# Altium schematic sheet ATT-Light.SchDoc(ATT-Light)
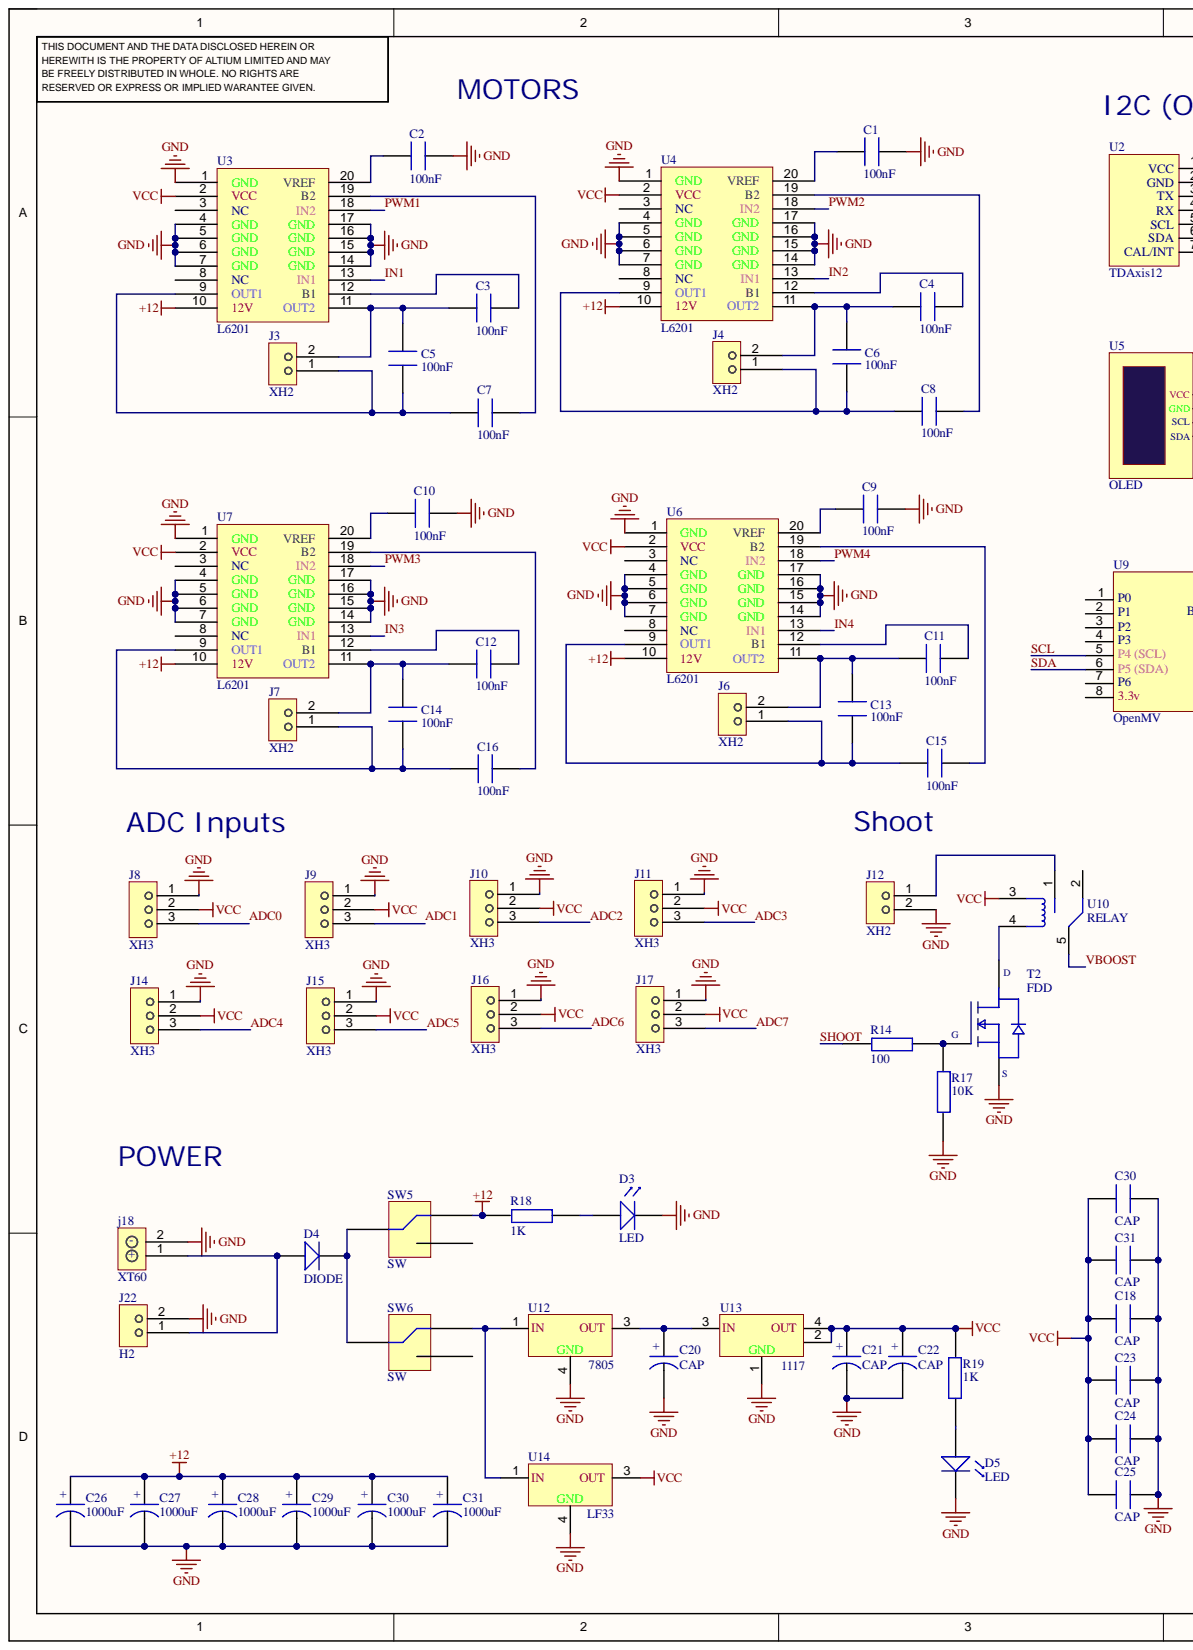
[diagram p0: ATT-Light.SchDoc, left]
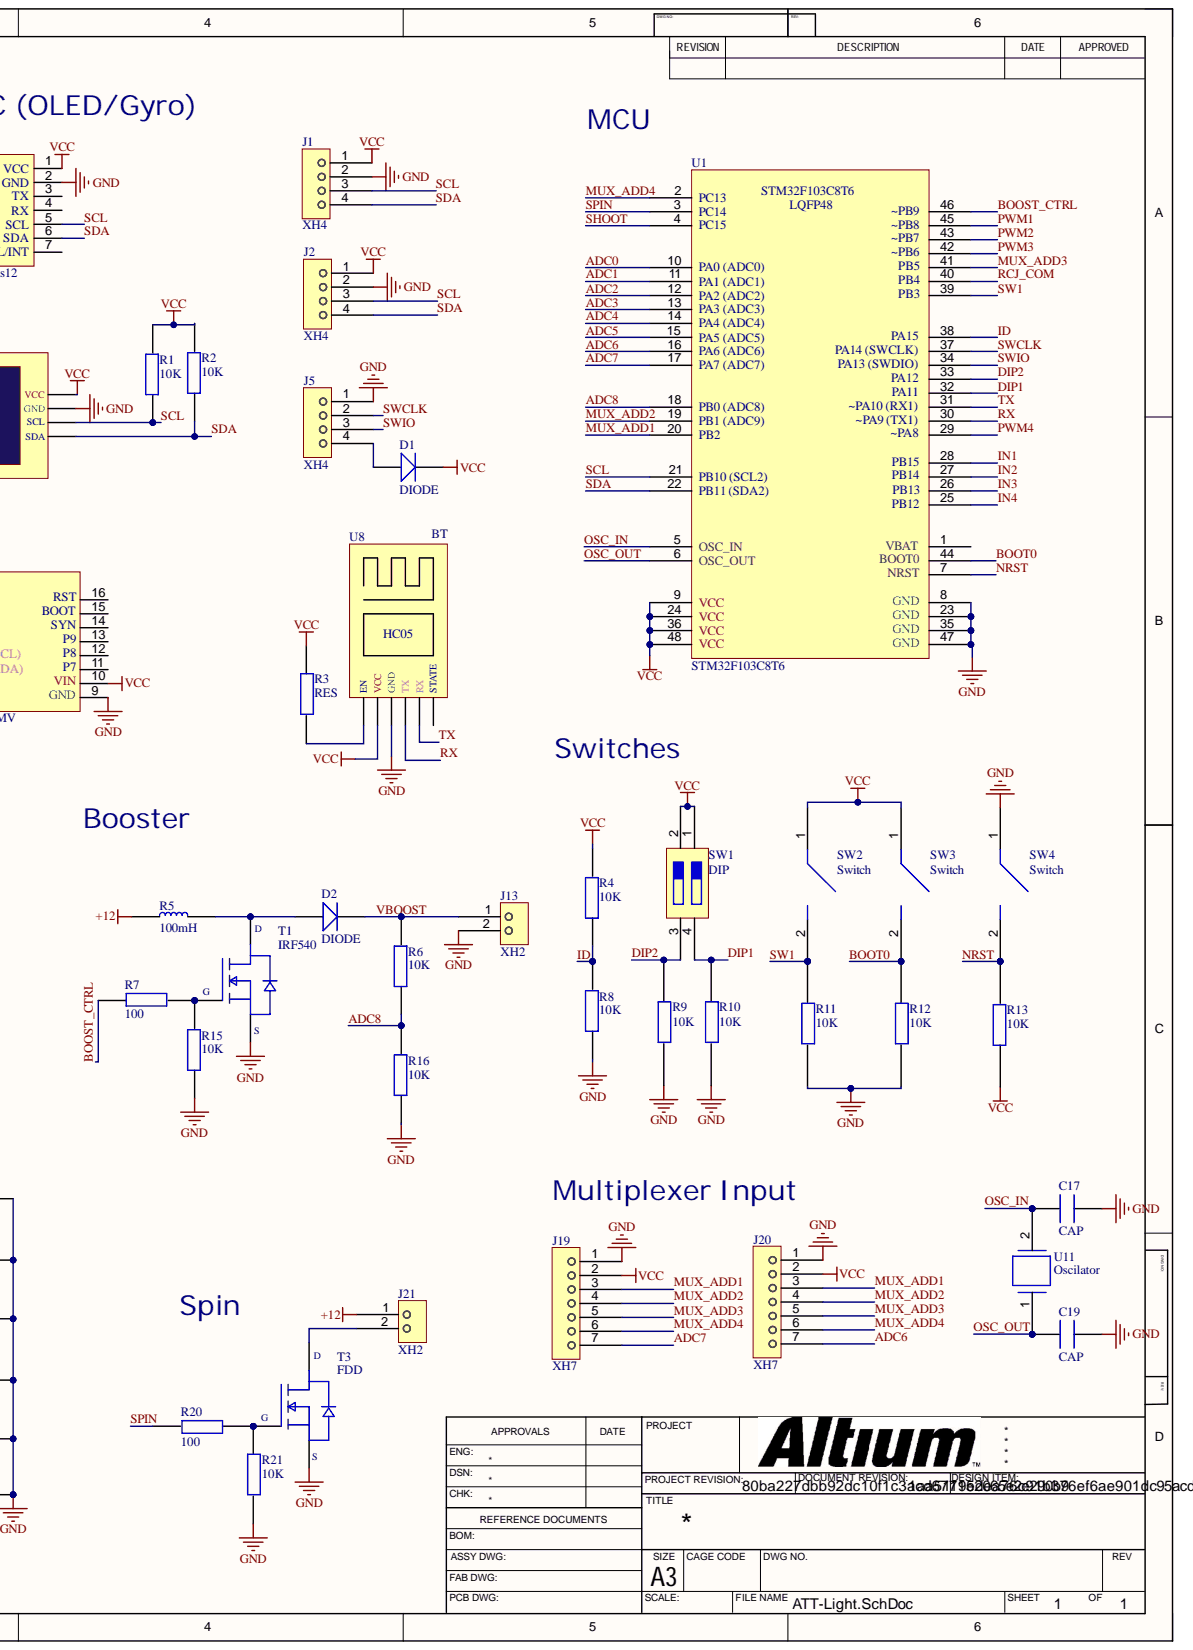
[diagram p1: ATT-Light.SchDoc, right]

## Parts
C1  100nF  [CAP1206]
C2  100nF  [CAP1206]
C3  100nF  [CAP1206]
C4  100nF  [CAP1206]
C5  100nF  [CAP1206]
C6  100nF  [CAP1206]
C7  100nF  [CAP1206]
C8  100nF  [CAP1206]
C9  100nF  [CAP1206]
C10  100nF  [CAP1206]
C11  100nF  [CAP1206]
C12  100nF  [CAP1206]
C13  100nF  [CAP1206]
C14  100nF  [CAP1206]
C15  100nF  [CAP1206]
C16  100nF  [CAP1206]
C17  CAP  [CAP1206]
C18  CAP  [CAP1206]
C19  CAP  [CAP1206]
C20  CAP  [CAP SMD 100uF 16V]
C21  CAP  [CAP SMD 100uF 16V]
C22  CAP  [CAP SMD 100uF 16V]
C23  CAP  [CAP1206]
C24  CAP  [CAP1206]
C25  CAP  [CAP1206]
C26  1000uF  [CAP 5x10x13 black]
C27  1000uF  [CAP 5x10x13 black]
C28  1000uF  [CAP 5x10x13 black]
C29  1000uF  [CAP 5x10x13 black]
C30  CAP  [CAP1206]
C30  1000uF  [CAP 5x10x13 black]
C31  CAP  [CAP1206]
C31  1000uF  [CAP 5x10x13 black]
D1  DIODE  [Diode]
D2  DIODE  [Diode]
D3  LED  [LED GREEN 1206]
D4  DIODE  [Diode]
D5  LED  [LED GREEN 1206]
J1  XH4  [XH 4PIN]
J2  XH4  [XH 4PIN]
J3  XH2  [XH 2pin]
J4  XH2  [XH 2pin]
J5  XH4  [XH 4PIN]
J6  XH2  [XH 2pin]
J7  XH2  [XH 2pin]
J8  XH3  [XH 3PIN]
J9  XH3  [XH 3PIN]
J10  XH3  [XH 3PIN]
J11  XH3  [XH 3PIN]
J12  XH2  [XH 2pin]
J13  XH2  [XH 2pin]
J14  XH3  [XH 3PIN]
J15  XH3  [XH 3PIN]
J16  XH3  [XH 3PIN]
J17  XH3  [XH 3PIN]
j18  XT60  [XT60]
J19  XH7  [XH 7PIN]
J20  XH7  [XH 7PIN]
J21  XH2  [XH 2pin]
J22  H2  [H2 MOLEX]
R1  10K  [RES1206]
R2  10K  [RES1206]
R3  RES  [RES1206]
R4  10K  [RES1206]
R5  100mH  [inductor]
R6  10K  [RES1206]
R7  100  [RES1206]
R8  10K  [RES1206]
R9  10K  [RES1206]
R10  10K  [RES1206]
R11  10K  [RES1206]
R12  10K  [RES1206]
R13  10K  [RES1206]
R14  100  [RES1206]
R15  10K  [RES1206]
R16  10K  [RES1206]
R17  10K  [RES1206]
R18  1K  [RES1206]
R19  1K  [RES1206]
R20  100  [RES1206]
R21  10K  [RES1206]
SW1  DIP  [DIP Switch 2]
SW2  Switch  [pushbutton]
SW3  Switch  [pushbutton]
SW4  Switch  [pushbutton]
SW5  SW  [SPDT Switch]
SW6  SW  [SPDT Switch]
T1  IRF540  [IRF540S]
T2  FDD  [FDD6690A]
T3  FDD  [FDD6690A]
U1  STM32F103C8T6  [STM32F103C8T6]
U2  TDAxis12  [TDAxis12]
U3  L6201  [L6201]
U4  L6201  [L6201]
U5  OLED  [OLED SH1106]
U6  L6201  [L6201]
U7  L6201  [L6201]
U8  BT  [HC05]
U9  OpenMV  [OpenMV]
U10  RELAY  [Relay 5V 10A]
U11  Oscilator  [Crystal Oscilator]
U12  7805  [7805 (SOT-252)]
U13  1117  [AMS1117]
U14  LF33  [LF33]

## Nets
+12: C26.2 C27.2 C28.2 C29.2 C30.2 C31.2 J21.1 R5.1 R18.2 SW5.1 U3.10(12V) U4.10(12V) U6.10(12V) U7.10(12V)
GND: C1.2 C2.2 C9.2 C10.2 C17.2 C18.1 C19.2 C20.1 C21.1 C22.1 C23.1 C24.1 C25.1 C26.1 C27.1 C28.1 C29.1 C30.1 C31.1 D3.2 D5.2 J1.2 J2.2 J5.1 J8.1 J9.1 J10.1 J11.1 J12.2 J13.2 J14.1 J15.1 J16.1 J17.1 j18.2 J19.1 J20.1 J22.2 R8.1 R9.2 R10.2 R11.2 R12.2 R15.1 R16.1 R17.2 R21.2 SW4.1 T1.3 T2.3 T3.3 U1.8(GND) U1.23(GND) U1.35(GND) U1.47(GND) U2.2(GND) U3.1(GND) U3.4(GND) U3.5(GND) U3.6(GND) U3.7(GND) U3.14(GND) U3.15(GND) U3.16(GND) U3.17(GND) U4.1(GND) U4.4(GND) U4.5(GND) U4.6(GND) U4.7(GND) U4.14(GND) U4.15(GND) U4.16(GND) U4.17(GND) U5.2(GND) U6.1(GND) U6.4(GND) U6.5(GND) U6.6(GND) U6.7(GND) U6.14(GND) U6.15(GND) U6.16(GND) U6.17(GND) U7.1(GND) U7.4(GND) U7.5(GND) U7.6(GND) U7.7(GND) U7.14(GND) U7.15(GND) U7.16(GND) U7.17(GND) U8.3(GND) U9.9(GND) U12.4 U13.1 U14.4
VCC: C18.2 C21.2 C22.2 C23.2 C24.2 C25.2 C30.2 C31.2 D1.2 J1.1 J2.1 J8.2 J9.2 J10.2 J11.2 J14.2 J15.2 J16.2 J17.2 J19.2 J20.2 R1.2 R2.2 R3.1 R4.2 R13.1 R19.2 SW1.1 SW1.2 SW2.1 SW3.1 U1.9(VCC) U1.24(VCC) U1.36(VCC) U1.48(VCC) U2.1(VCC) U3.2(VCC) U4.2(VCC) U5.1(VCC) U6.2(VCC) U7.2(VCC) U8.2(VCC) U9.10(VIN) U10.3 U13.2 U13.4(OUT) U14.3
ADC0: J8.3 U1.10(PA0_(ADC0))
ADC1: J9.3 U1.11(PA1_(ADC1))
ADC2: J10.3 U1.12(PA2_(ADC2))
ADC3: J11.3 U1.13(PA3_(ADC3))
ADC4: J14.3 U1.14(PA4_(ADC4))
ADC5: J15.3 U1.15(PA5_(ADC5))
ADC6: J16.3 J20.7 U1.16(PA6_(ADC6))
ADC7: J17.3 J19.7 U1.17(PA7_(ADC7))
ADC8: R6.1 R16.2 U1.18(PB0_(ADC8))
BOOST_CTRL: R7.1 U1.46(~PB9)
BOOT0: R12.1 SW3.2 U1.44(BOOT0)
DIP1: R10.1 SW1.4 U1.32(PA11)
DIP2: R9.1 SW1.3 U1.33(PA12)
ID: R4.1 R8.2 U1.38(PA15)
IN1: U1.28(PB15) U3.13(IN1)
IN2: U1.27(PB14) U4.13(IN1)
IN3: U1.26(PB13) U7.13(IN1)
IN4: U1.25(PB12) U6.13(IN1)
MUX_ADD1: J19.3 J20.3 U1.20(PB2)
MUX_ADD2: J19.4 J20.4 U1.19(PB1_(ADC9))
MUX_ADD3: J19.5 J20.5 U1.41(PB5)
MUX_ADD4: J19.6 J20.6 U1.2(PC13)
NRST: R13.2 SW4.2 U1.7(NRST)
OSC_IN: C17.1 U1.5(OSC_IN) U11.2
OSC_OUT: C19.1 U1.6(OSC_OUT) U11.1
PWM1: U1.45(~PB8) U3.18(IN2)
PWM2: U1.43(~PB7) U4.18(IN2)
PWM3: U1.42(~PB6) U7.18(IN2)
PWM4: U1.29(~PA8) U6.18(IN2)
RCJ_COM: U1.40(PB4)
RX: U1.30(~PA9_(TX1)) U8.4(TX)
SCL: J1.3 J2.3 R1.1 U1.21(PB10_(SCL2)) U2.5(SCL) U5.3(SCL) U9.5(P4_(SCL))
SDA: J1.4 J2.4 R2.1 U1.22(PB11_(SDA2)) U2.6(SDA) U5.4(SDA) U9.6(P5_(SDA))
SHOOT: R14.2 U1.4(PC15)
SPIN: R20.2 U1.3(PC14)
SW1: R11.1 SW2.2 U1.39(PB3)
SWCLK: J5.2 U1.37(PA14_(SWCLK))
SWIO: J5.3 U1.34(PA13_(SWDIO))
TX: U1.31(~PA10_(RX1)) U8.5(RX)
VBOOST: D2.2 J13.1 R6.2 U10.5
NetC1_1: C1.1 U4.20(VREF)
NetC2_1: C2.1 U3.20(VREF)
NetC3_1: C3.1 U3.12(B1)
NetC3_2: C3.2 C5.2 J3.2 U3.11(OUT2)
NetC4_1: C4.1 U4.12(B1)
NetC4_2: C4.2 C6.2 J4.2 U4.11(OUT2)
NetC5_1: C5.1 C7.1 J3.1 U3.9(OUT1)
NetC6_1: C6.1 C8.1 J4.1 U4.9(OUT1)
NetC7_2: C7.2 U3.19(B2)
NetC8_2: C8.2 U4.19(B2)
NetC9_1: C9.1 U6.20(VREF)
NetC10_1: C10.1 U7.20(VREF)
NetC11_1: C11.1 U6.12(B1)
NetC11_2: C11.2 C13.2 J6.2 U6.11(OUT2)
NetC12_1: C12.1 U7.12(B1)
NetC12_2: C12.2 C14.2 J7.2 U7.11(OUT2)
NetC13_1: C13.1 C15.1 J6.1 U6.9(OUT1)
NetC14_1: C14.1 C16.1 J7.1 U7.9(OUT1)
NetC15_2: C15.2 U6.19(B2)
NetC16_2: C16.2 U7.19(B2)
NetC20_2: C20.2 U12.3 U13.3(IN)
NetD1_1: D1.1 J5.4
NetD2_1: D2.1 R5.2 T1.4
NetD3_1: D3.1 R18.1
NetD4_1: D4.1 j18.1 J22.1
NetD4_2: D4.2 SW5.2 SW6.2
NetD5_1: D5.1 R19.1
NetJ12_1: J12.1 U10.1
NetJ21_2: J21.2 T3.4
NetR3_2: R3.2 U8.1(EN)
NetR7_2: R7.2 R15.2 T1.1
NetR14_1: R14.1 R17.1 T2.1
NetR20_1: R20.1 R21.1 T3.1
NetSW6_1: SW6.1 U12.1 U14.1
NetT2_4: T2.4 U10.4

Not connected: SW5 3; SW6 3; U1 1(VBAT); U2 3(TX) 4(RX) 7(CAL/INT); U3 3(NC) 8(NC); U4 3(NC) 8(NC); U6 3(NC) 8(NC); U7 3(NC) 8(NC); U8 6(STATE); U9 1(P0) 2(P1) 3(P2) 4(P3) 7(P6) 8(3.3v) 11(P7) 12(P8) 13(P9) 14(SYN) 15(BOOT) 16(RST); U10 2

## Library data of the parts
C1-C19 C23-C25 C30 C31 = CAP1206: Capacitor SMD 1206; Component Kind: Standard; Library Name: Farhan.IntLib
C20-C22 = CAP Electrolit SMD: Capacitor Electrolit SMD; Component Kind: Standard; Library Name: Farhan.IntLib
C26-C31 = Cap Electrolyte: CAP DIP; Component Kind: Standard; Library Name: Farhan.IntLib
D3 D5 = LED SMD 1206: Component Kind: Standard; Description: LED SMD 1206; Library Name: Farhan.IntLib
J1 J2 J5 = XH 4PIN: XH Connector 4pins; Component Kind: Standard; Library Name: Farhan.IntLib
J3 J4 J6 J7 J12 J13 J21 = XH 2PIN: XH Connector 2pins; Component Kind: Standard; Library Name: Farhan.IntLib
J8-J11 J14-J17 = XH 3PIN: XH Connector 3pins; Component Kind: Standard; Library Name: Farhan.IntLib
j18 = XT60: XT60 Power Connector; Component Kind: Standard; Library Name: Farhan.IntLib
J19 J20 = XH 7Pin: XH Connector 7pins; Component Kind: Standard; Library Name: Farhan.IntLib
J22 = H2 MOLEX: molex connector; Component Kind: Standard; Library Name: Farhan.IntLib
R1-R4 R6-R21 = RES1206: RESISTOR SMD; Component Kind: Standard; Library Name: Farhan.IntLib
R5 = inductor: SELF INDUCTOR; Component Kind: Standard; Library Name: Farhan.IntLib
SW1 = DIP SW 2: DIP Switch 2 pin; Component Kind: Standard; Library Name: Farhan.IntLib
SW2-SW4 = pushbutton: Switch SMD Tact; Component Kind: Standard; Library Name: Farhan.IntLib
SW5 SW6 = SPDT Switch: SPDT Power Switch; Component Kind: Standard; Library Name: Farhan.IntLib
T1 = IRF540S: IRF-540 MOSFET N-Chanel; Component Kind: Standard; Library Name: Farhan.IntLib
T2 T3 = FDD6690A: FDD6690A MOSFET N-Chanel; Component Kind: Standard; Library Name: Farhan.IntLib
U1 = STM32F103C8T6: STM32F103C8T6  LQFP48; Component Kind: Standard; Library Name: Farhan.IntLib
U2 = TDAxis12: Gyroscpoe Sensor; Component Kind: Standard; Library Name: Farhan.IntLib
U5 = OLED SH1106: OLED SH1106 1.3inch; Component Kind: Standard; Library Name: Farhan.IntLib
U8 = HC05: Bluetooth HC05 Module; Component Kind: Standard; Library Name: Farhan.IntLib
U9 = OpenMV: OpenMV Camera H7 R2; Component Kind: Standard; Library Name: Farhan.IntLib
U10 = Relay 5V 10A: Component Kind: Standard; Description: RELAY; Library Name: Farhan.IntLib
U11 = Crystal: Crystal Oscilator 8MHz; Component Kind: Standard; Library Name: Farhan.IntLib
U12 = 7805 (SOT-252): 5v Regulator; Component Kind: Standard; Library Name: Farhan.IntLib
U13 = AMS1117: 3.3v Regulator; Component Kind: Standard; Library Name: Farhan.IntLib
U14 = LF33: 3.3V Regulator; Component Kind: Standard; Library Name: Farhan.IntLib
D1 D2 D4 = Diode: Component Kind: Standard; Description: DIODE; Library Name: Farhan.IntLib
U3 U4 U6 U7 = L6201: Component Kind: Standard; Description: L6201; Library Name: Farhan.IntLib
